# Altium schematic sheet Gravity Expansion Shield for Joule V1.0.SchDoc
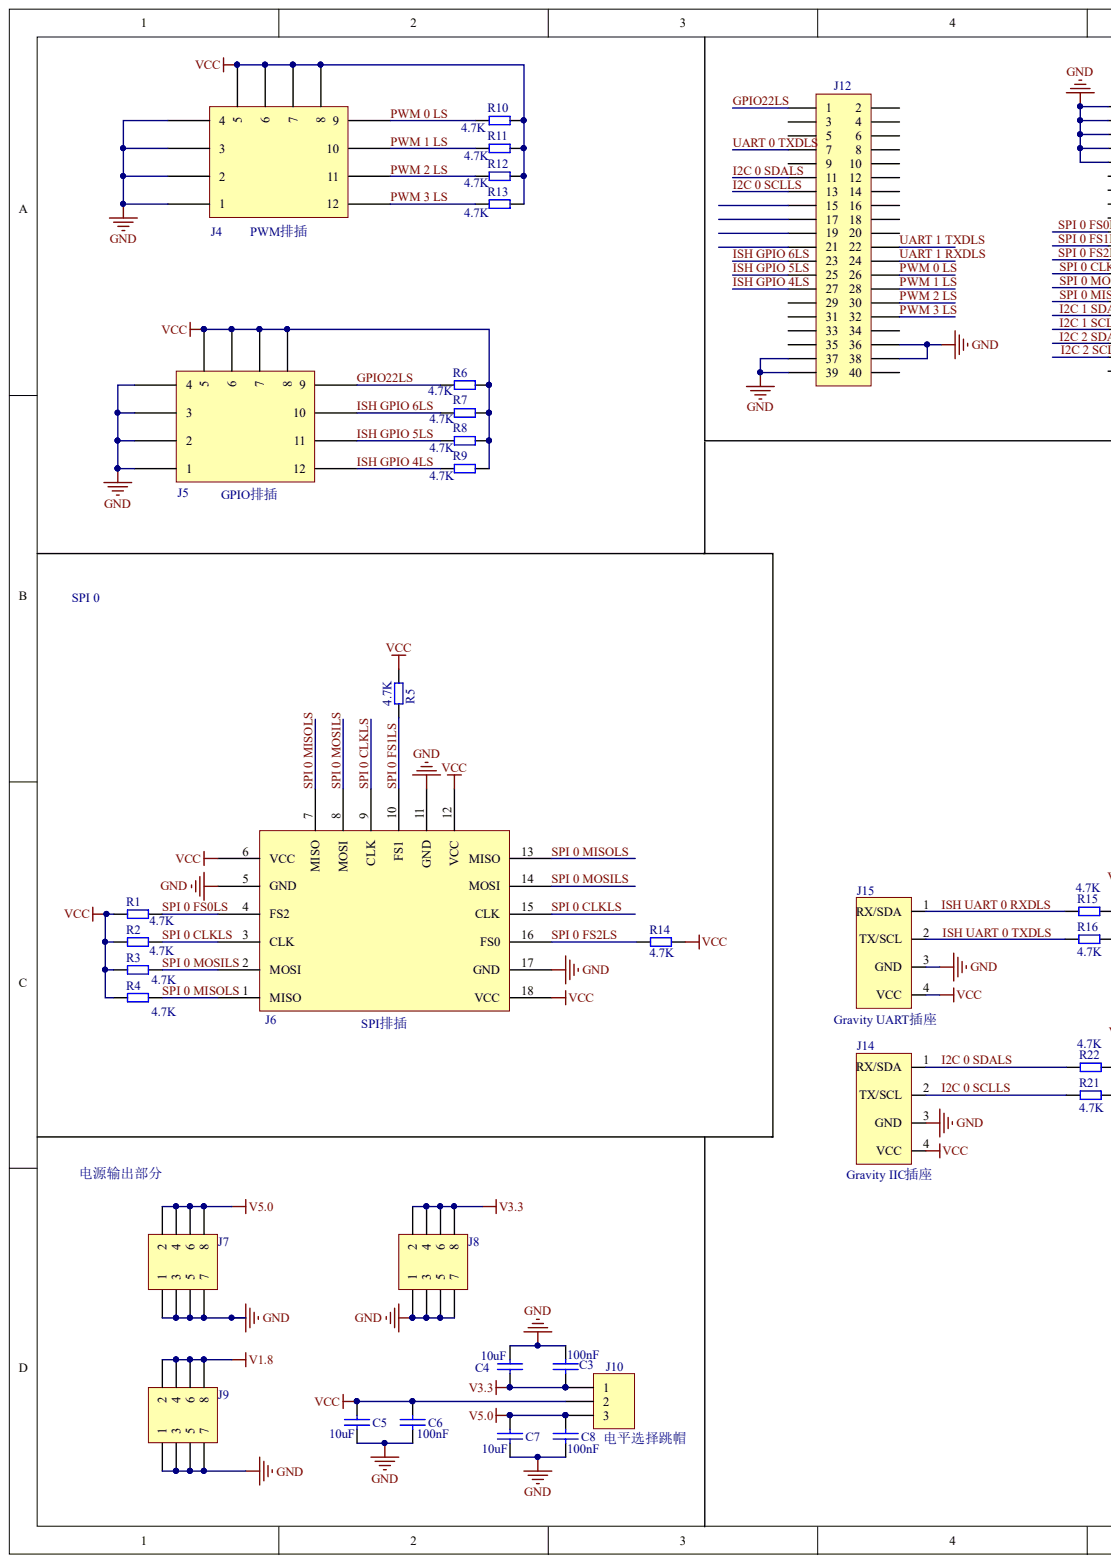
[diagram p0: Gravity Expansion Shield for Joule V1.0.SchDoc, left]
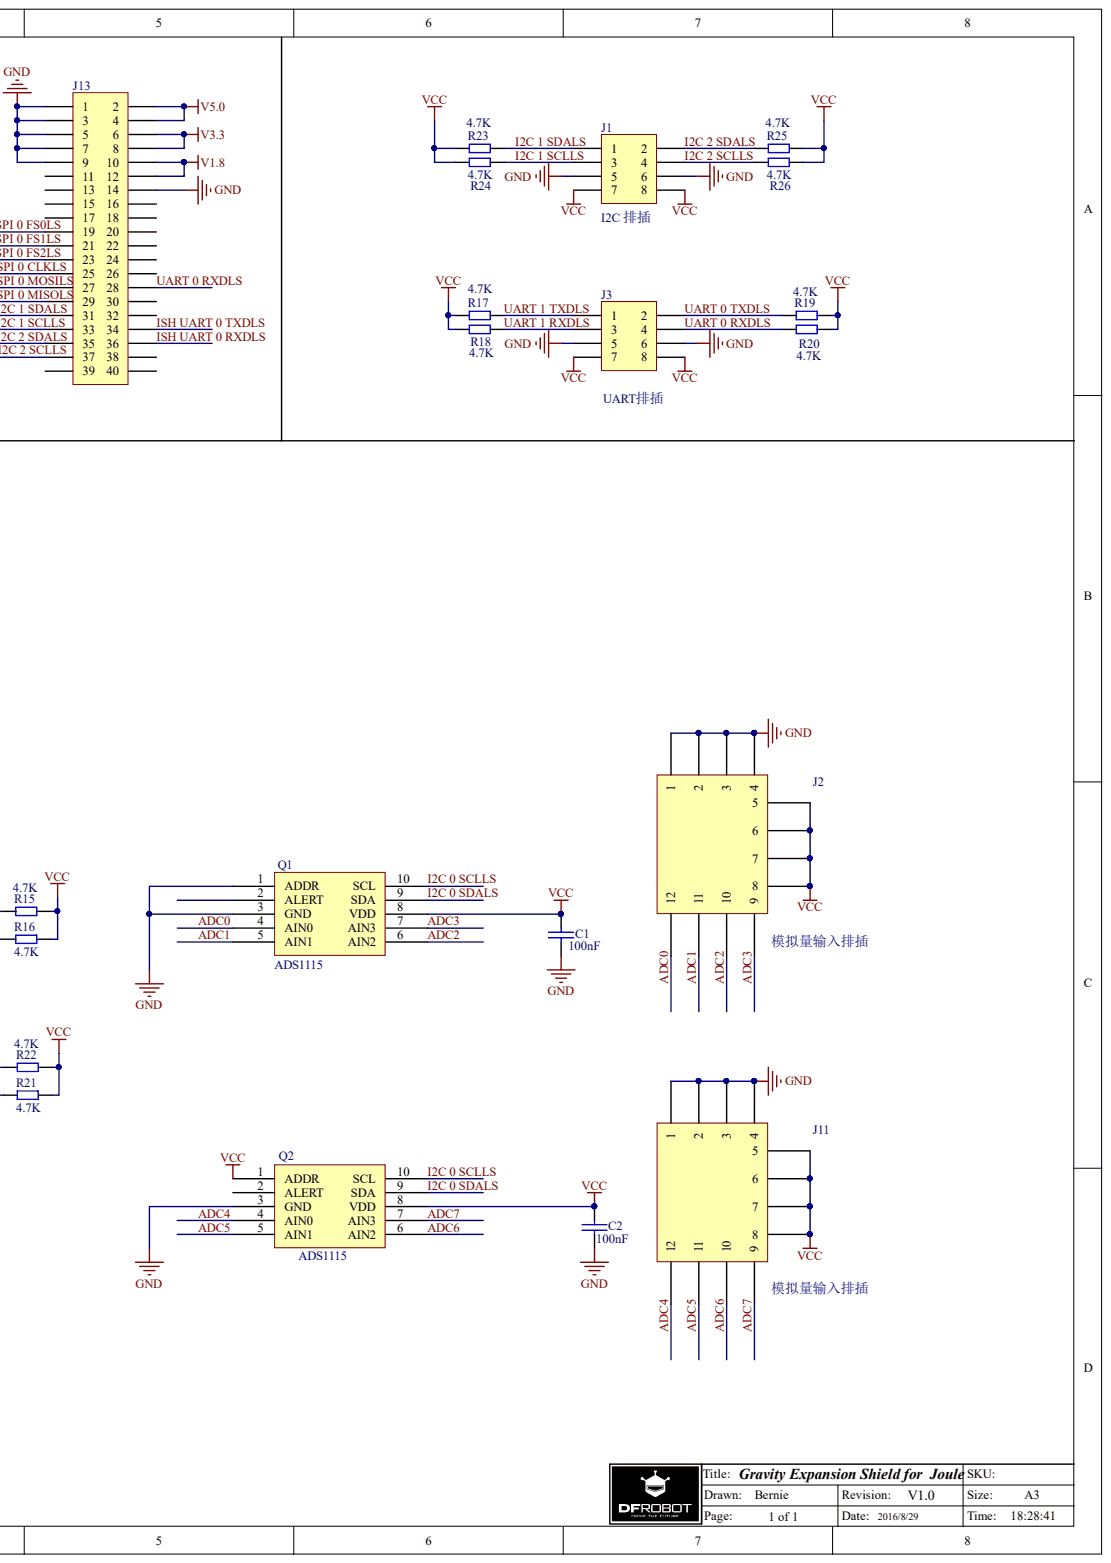
[diagram p1: Gravity Expansion Shield for Joule V1.0.SchDoc, right]

## Parts
C1  贴片电容0603-100nF-16V-X7R  [CAPC_0603]  (value not drawn)
C2  name: 100nF  [CAPC_0603]  (hidden comment: 贴片电容0603-100nF-16V-X7R)
C3  name: 100nF  [CAPC_0603]  (hidden comment: 贴片电容0603-100nF-16V-X7R)
C4  name: 10uF  [CAPC_0603]  (hidden comment: 贴片电容0603-10uF-50V-X7R)
C5  name: 10uF  [CAPC_0603]  (hidden comment: 贴片电容0603-10uF-50V-X7R)
C6  name: 100nF  [CAPC_0603]  (hidden comment: 贴片电容0603-100nF-16V-X7R)
C7  name: 10uF  [CAPC_0603]  (hidden comment: 贴片电容0603-10uF-50V-X7R)
C8  name: 100nF  [CAPC_0603]  (hidden comment: 贴片电容0603-100nF-16V-X7R)
J1  name: I2C 排插  [HDR2X4]  (hidden comment: 2.54黑色双排针2*4P)
J2  name: 模拟量输入排插  (hidden comment: 2.54黑色三排排针3*4)
J3  2.54黑色双排针2*4P  [HDR2X4]  (value not drawn)
J4  2.54黑色三排排针3*4  (value not drawn)
J5  2.54黑色三排排针3*4  (value not drawn)
J6  2.54三色三排针3*6P  (value not drawn)
J7  2.54黑色双排针2*4P  [HDR2X4 -PWR]  (value not drawn)
J8  2.54黑色双排针2*4P  [HDR2X4 -PWR]  (value not drawn)
J9  2.54黑色双排针2*4P  [HDR2X4 -PWR]  (value not drawn)
J10  name: 电平选择跳帽  [HDR1X3-jumper]  (hidden comment: 2.54红色单排排针-3P)
J11  name: 模拟量输入排插  (hidden comment: 2.54黑色三排排针3*4)
J12  2.54黑色长脚双排针2*20P 15mm  [HDR2X20_2.54]  (value not drawn)
J13  2.54黑色长脚双排针2*20P 15mm  [HDR2X20_2.54]  (value not drawn)
J14  PH2.0-4PWT卧式贴片座  (value not drawn)
J15  PH2.0-4PWT卧式贴片座  (value not drawn)
Q1  name: ADS1115  (hidden comment: ADS1115IDGSR-ADC转换芯片)
Q2  name: ADS1115  (hidden comment: ADS1115IDGSR-ADC转换芯片)
R1  name: 4.7K  [RES_0603]  (hidden comment: 贴片电阻0603-4.7K-5%)
R2  name: 4.7K  [RES_0603]  (hidden comment: 贴片电阻0603-4.7K-5%)
R3  name: 4.7K  [RES_0603]  (hidden comment: 贴片电阻0603-4.7K-5%)
R4  name: 4.7K  [RES_0603]  (hidden comment: 贴片电阻0603-4.7K-5%)
R5  name: 4.7K  [RES_0603]  (hidden comment: 贴片电阻0603-4.7K-5%)
R6  name: 4.7K  [RES_0603]  (hidden comment: 贴片电阻0603-4.7K-5%)
R7  name: 4.7K  [RES_0603]  (hidden comment: 贴片电阻0603-4.7K-5%)
R8  name: 4.7K  [RES_0603]  (hidden comment: 贴片电阻0603-4.7K-5%)
R9  name: 4.7K  [RES_0603]  (hidden comment: 贴片电阻0603-4.7K-5%)
R10  name: 4.7K  [RES_0603]  (hidden comment: 贴片电阻0603-4.7K-5%)
R11  name: 4.7K  [RES_0603]  (hidden comment: 贴片电阻0603-4.7K-5%)
R12  name: 4.7K  [RES_0603]  (hidden comment: 贴片电阻0603-4.7K-5%)
R13  name: 4.7K  [RES_0603]  (hidden comment: 贴片电阻0603-4.7K-5%)
R14  name: 4.7K  [RES_0603]  (hidden comment: 贴片电阻0603-4.7K-5%)
R15  name: 4.7K  [RES_0603]  (hidden comment: 贴片电阻0603-4.7K-5%)
R16  name: 4.7K  [RES_0603]  (hidden comment: 贴片电阻0603-4.7K-5%)
R17  name: 4.7K  [RES_0603]  (hidden comment: 贴片电阻0603-4.7K-5%)
R18  name: 4.7K  [RES_0603]  (hidden comment: 贴片电阻0603-4.7K-5%)
R19  name: 4.7K  [RES_0603]  (hidden comment: 贴片电阻0603-4.7K-5%)
R20  name: 4.7K  [RES_0603]  (hidden comment: 贴片电阻0603-4.7K-5%)
R21  name: 4.7K  [RES_0603]  (hidden comment: 贴片电阻0603-4.7K-5%)
R22  name: 4.7K  [RES_0603]  (hidden comment: 贴片电阻0603-4.7K-5%)
R23  name: 4.7K  [RES_0603]  (hidden comment: 贴片电阻0603-4.7K-5%)
R24  name: 4.7K  [RES_0603]  (hidden comment: 贴片电阻0603-4.7K-5%)
R25  name: 4.7K  [RES_0603]  (hidden comment: 贴片电阻0603-4.7K-5%)
R26  name: 4.7K  [RES_0603]  (hidden comment: 贴片电阻0603-4.7K-5%)

## Nets
GND: C1.2 C2.2 C3.2 C4.2 C5.2 C6.2 C7.2 C8.2 J1.5 J1.6 J2.1 J2.2 J2.3 J2.4 J3.5 J3.6 J4.1 J4.2 J4.3 J4.4 J5.1 J5.2 J5.3 J5.4 J6.5(GND) J6.11(GND) J6.17(GND) J7.1 J7.3 J7.5 J7.7 J8.1 J8.3 J8.5 J8.7 J9.1 J9.3 J9.5 J9.7 J11.1 J11.2 J11.3 J11.4 J12.36 J12.37 J12.38 J12.39 J13.1 J13.3 J13.5 J13.7 J13.9 J13.14 J14.3(GND) J15.3(GND) Q1.1(ADDR) Q1.3(GND) Q2.3(GND)
V1.8: J9.2 J9.4 J9.6 J9.8 J13.10 J13.12
V3.3: C3.1 C4.1 J8.2 J8.4 J8.6 J8.8 J10.1 J13.6 J13.8
V5.0: C7.1 C8.1 J7.2 J7.4 J7.6 J7.8 J10.3 J13.2 J13.4
VCC: C1.1 C2.1 C5.1 C6.1 J1.7 J1.8 J2.5 J2.6 J2.7 J2.8 J3.7 J3.8 J4.5 J4.6 J4.7 J4.8 J5.5 J5.6 J5.7 J5.8 J6.6(VCC) J6.12(VCC) J6.18(VCC) J10.2 J11.5 J11.6 J11.7 J11.8 J14.4(VCC) J15.4(VCC) Q1.8(VDD) Q2.1(ADDR) Q2.8(VDD) R1.1 R2.1 R3.1 R4.1 R5.1 R6.1 R7.1 R8.1 R9.1 R10.1 R11.1 R12.1 R13.1 R14.1 R15.1 R16.1 R17.2 R18.2 R19.1 R20.1 R21.1 R22.1 R23.2 R24.1 R25.1 R26.2
ADC0: J2.12 Q1.4(AIN0)
ADC1: J2.11 Q1.5(AIN1)
ADC2: J2.10 Q1.6(AIN2)
ADC3: J2.9 Q1.7(AIN3)
ADC4: J11.12 Q2.4(AIN0)
ADC5: J11.11 Q2.5(AIN1)
ADC6: J11.10 Q2.6(AIN2)
ADC7: J11.9 Q2.7(AIN3)
GPIO22LS: J5.9 J12.1 R6.2
I2C 0 SCLLS: J12.13 J14.2(TX/SCL) Q1.10(SCL) Q2.10(SCL) R21.2
I2C 0 SDALS: J12.11 J14.1(RX/SDA) Q1.9(SDA) Q2.9(SDA) R22.2
I2C 1 SCLLS: J1.3 J13.33 R24.2
I2C 1 SDALS: J1.1 J13.31 R23.1
I2C 2 SCLLS: J1.4 J13.37 R26.1
I2C 2 SDALS: J1.2 J13.35 R25.2
ISH GPIO 4LS: J5.12 J12.27 R9.2
ISH GPIO 5LS: J5.11 J12.25 R8.2
ISH GPIO 6LS: J5.10 J12.23 R7.2
ISH UART 0 RXDLS: J13.36 J15.1(RX/SDA) R15.2
ISH UART 0 TXDLS: J13.34 J15.2(TX/SCL) R16.2
PWM 0 LS: J4.9 J12.26 R10.2
PWM 1 LS: J4.10 J12.28 R11.2
PWM 2 LS: J4.11 J12.30 R12.2
PWM 3 LS: J4.12 J12.32 R13.2
SPI 0 CLKLS: J6.3(CLK) J6.9(CLK) J6.15(CLK) J13.25 R2.2
SPI 0 FS0LS: J6.4(FS2) J13.19 R1.2
SPI 0 FS1LS: J6.10(FS1) J13.21 R5.2
SPI 0 FS2LS: J6.16(FS0) J13.23 R14.2
SPI 0 MISOLS: J6.1(MISO) J6.7(MISO) J6.13(MISO) J13.29 R4.2
SPI 0 MOSILS: J6.2(MOSI) J6.8(MOSI) J6.14(MOSI) J13.27 R3.2
UART 0 RXDLS: J3.4 J13.28 R20.2
UART 0 TXDLS: J3.2 J12.7 R19.2
UART 1 RXDLS: J3.3 J12.24 R18.1
UART 1 TXDLS: J3.1 J12.22 R17.1

Not connected: J12 2 3 4 5 6 8 9 10 12 14 15 16 17 18 19 20 21 29 31 33 34 35 40; J13 11 13 15 16 17 18 20 22 24 26 30 32 38 39 40; Q1 2(ALERT); Q2 2(ALERT)

## Library data of the parts
C1-C8 = CAP: Capacitor; paixu: 2; Component Kind: Standard; Library Name: DFRobot_Sch.SchLib
  C1: : 100nF
J1 J7-J9 = Header 4X2: Header, 4-Pin, Dual row; paixu: 5; Component Kind: Standard; LatestRevisionDate: 17-Jul-2002; LatestRevisionNote: Re-released for DXP Platform.; Library Name: Miscellaneous Connectors.IntLib; Publisher: Altium Limited
  J1: Signal Integrity: Connector
J2 J11 = Gravity 3PIN*4_2.54: paixu: 5; Component Kind: Standard; Library Name: DFRobot_Sch.SchLib
J3 = Header 4X2: Header, 4-Pin, Dual row; name: UART排插; paixu: 5; Component Kind: Standard; LatestRevisionDate: 17-Jul-2002; LatestRevisionNote: Re-released for DXP Platform.; Library Name: Miscellaneous Connectors.IntLib; Signal Integrity: Connector; Publisher: Altium Limited
J4 = Gravity 3PIN*4_2.54: name: PWM排插; paixu: 5; Component Kind: Standard; Library Name: DFRobot_Sch.SchLib
J5 = Gravity 3PIN*4_2.54: name: GPIO排插; paixu: 5; Component Kind: Standard; Library Name: DFRobot_Sch.SchLib
J6 = SPI_6PIN*3_2.54: name: SPI排插; paixu: 5; Component Kind: Standard; Library Name: DFRobot_Sch.SchLib
J10 = Header 3H: Header, 3-Pin, Right Angle; paixu: 5; Component Kind: Standard; LatestRevisionDate: 17-Jul-2002; LatestRevisionNote: Re-released for DXP Platform.; Library Name: Miscellaneous Connectors.IntLib; Signal Integrity: Connector; Publisher: Altium Limited
J12 J13 = Header 20X2: Header, 20-Pin, Dual row; paixu: 5; Component Kind: Standard; LatestRevisionDate: 17-Jul-2002; LatestRevisionNote: Re-released for DXP Platform.; Library Name: Miscellaneous Connectors.IntLib; Publisher: Altium Limited
J14 = I2C UART 4PIN: name: Gravity IIC插座; paixu: 5; Component Kind: Standard; Library Name: DFRobot_Sch.SchLib
J15 = I2C UART 4PIN: name: Gravity UART插座; paixu: 5; Component Kind: Standard; Library Name: DFRobot_Sch.SchLib
Q1 Q2 = SOP10: paixu: 3; Component Kind: Standard; Library Name: DFRobot_Sch.SchLib; URL: https://detail.tmall.com/item.htm?id=21375699352&spm=a1z09.2.0.0.FqqrN4&_u=fn0mb37f2a4
R1-R26 = RES: paixu: 1; Component Kind: Standard; Description: RES; Library Name: DFRobot_Sch.SchLib
